# Altium schematic sheet Connector.SchDoc
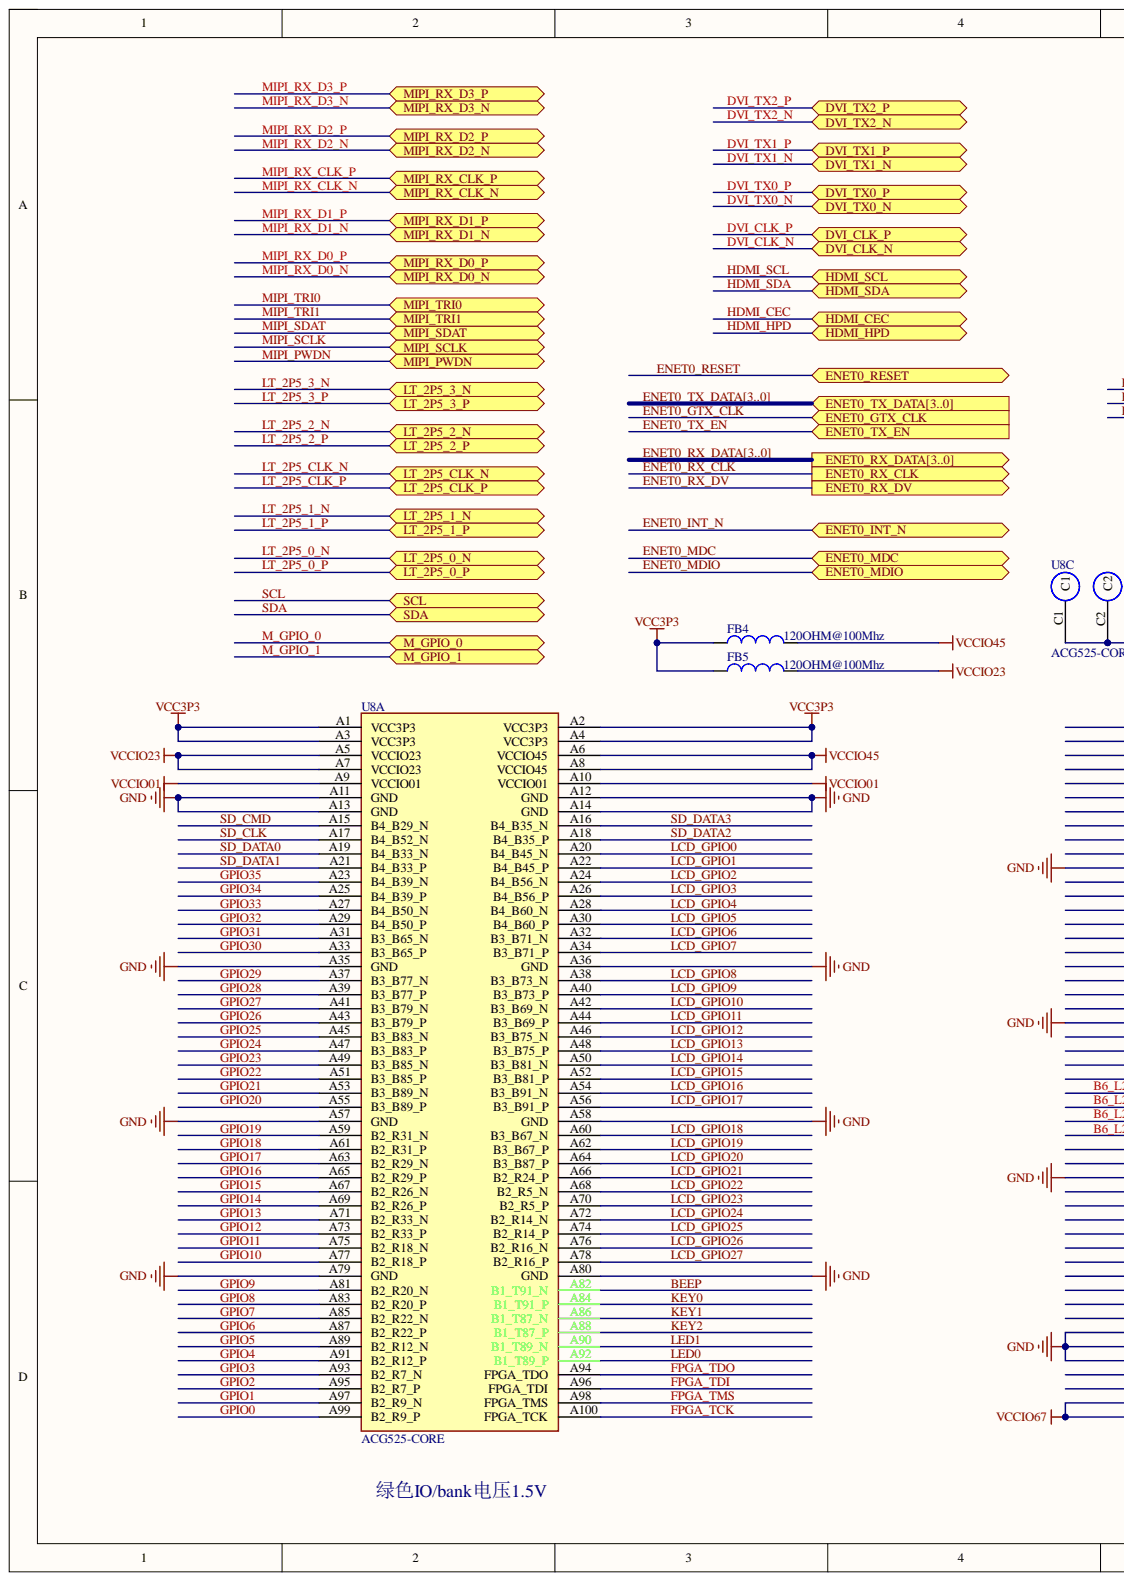
[diagram p0: Connector.SchDoc, left]
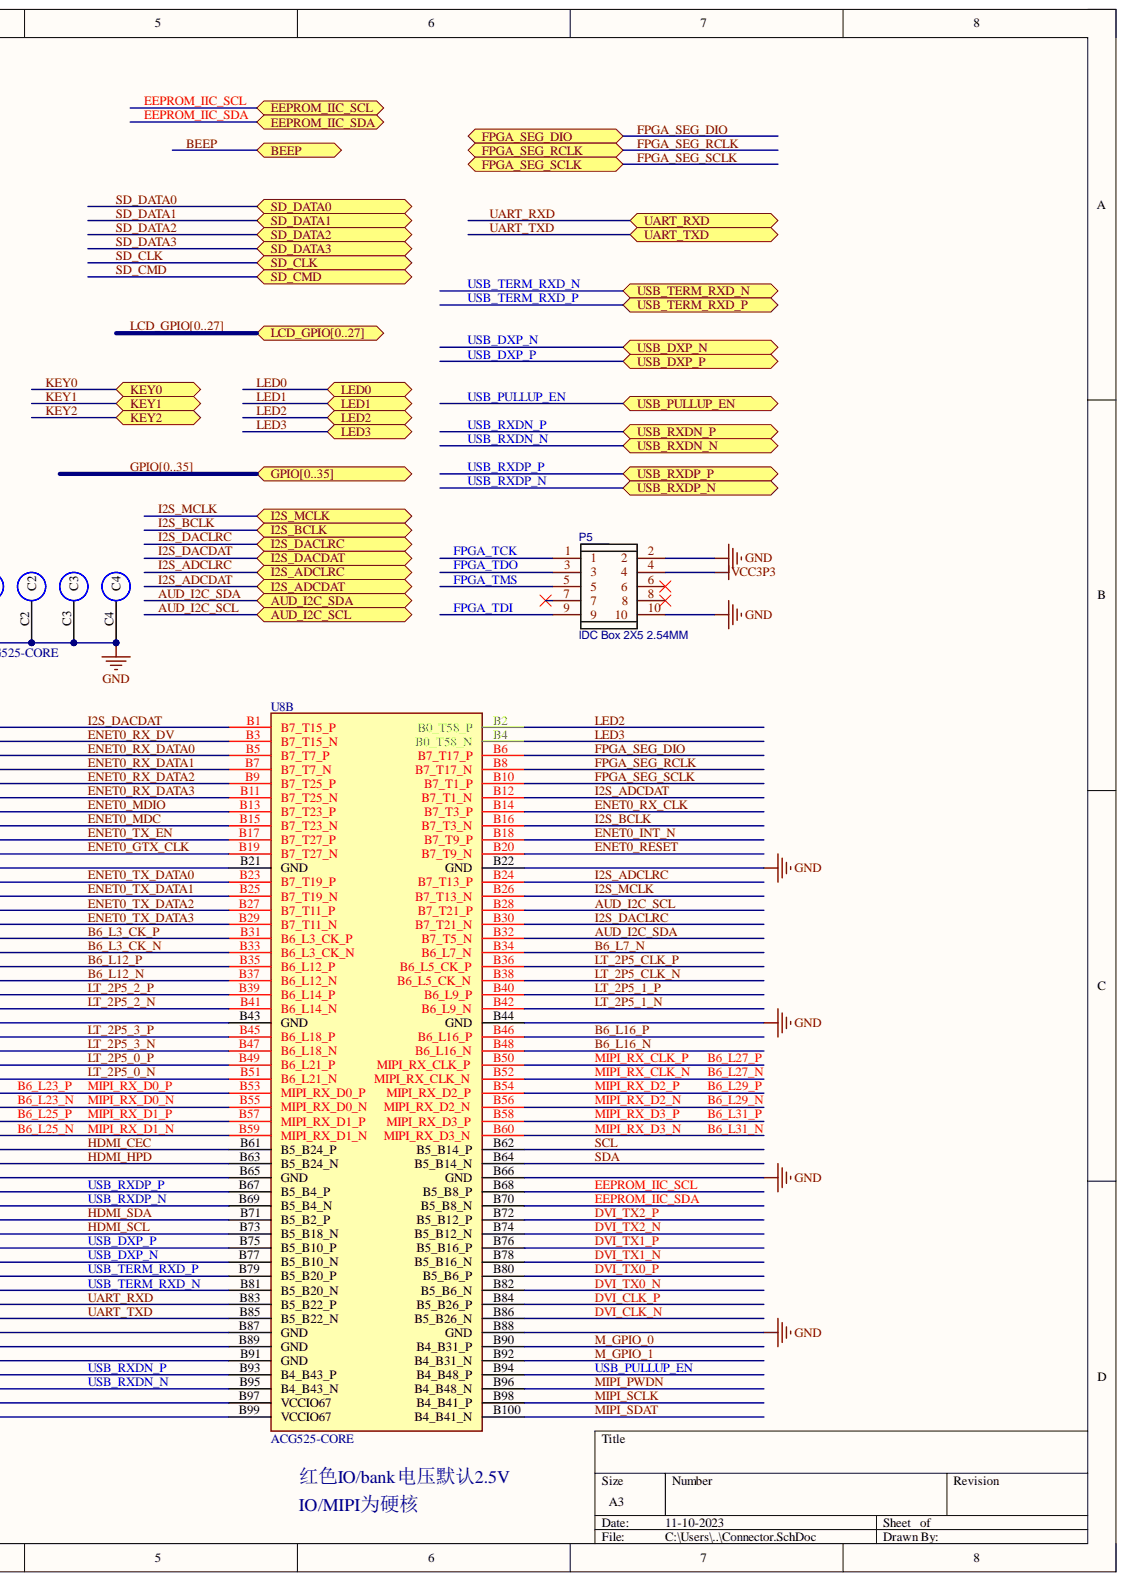
[diagram p1: Connector.SchDoc, right]

## Parts
FB4  120OHM@100Mhz  [L0603]
FB5  120OHM@100Mhz  [L0603]
P5  IDC Box 2X5 2.54MM  [idc-th_10p-p2_54-h-r2-c5-s2_54]
U8  ACG525-CORE  [ACG525-CORE-1110]  (parts A,B,C)

## Ports
AUD_I2C_SCL, AUD_I2C_SDA, BEEP, DVI_CLK_N, DVI_CLK_P, DVI_TX0_N, DVI_TX0_P, DVI_TX1_N, DVI_TX1_P, DVI_TX2_N, DVI_TX2_P, EEPROM_IIC_SCL, EEPROM_IIC_SDA, ENET0_GTX_CLK, ENET0_INT_N, ENET0_MDC, ENET0_MDIO, ENET0_RESET, ENET0_RX_CLK, ENET0_RX_DATA0, ENET0_RX_DATA1, ENET0_RX_DATA2, ENET0_RX_DATA3, ENET0_RX_DATA[3..0], ENET0_RX_DV, ENET0_TX_DATA0, ENET0_TX_DATA1, ENET0_TX_DATA2, ENET0_TX_DATA3, ENET0_TX_DATA[3..0], ENET0_TX_EN, FPGA_SEG_DIO, FPGA_SEG_RCLK, FPGA_SEG_SCLK, GPIO0, GPIO1, GPIO2, GPIO3, GPIO4, GPIO5, GPIO6, GPIO7, GPIO8, GPIO9, GPIO10, GPIO11, GPIO12, GPIO13, GPIO14, GPIO15, GPIO16, GPIO17, GPIO18, GPIO19, GPIO20, GPIO21, GPIO22, GPIO23, GPIO24, GPIO25, GPIO26, GPIO27, GPIO28, GPIO29, GPIO30, GPIO31, GPIO32, GPIO33, GPIO34, GPIO35, GPIO[0..35], HDMI_CEC, HDMI_HPD, HDMI_SCL, HDMI_SDA, I2S_ADCDAT, I2S_ADCLRC, I2S_BCLK, I2S_DACDAT, I2S_DACLRC, I2S_MCLK, KEY0, KEY1, KEY2, LCD_GPIO0, LCD_GPIO1, LCD_GPIO2, LCD_GPIO3, LCD_GPIO4, LCD_GPIO5, LCD_GPIO6, LCD_GPIO7, LCD_GPIO8, LCD_GPIO9, LCD_GPIO10, LCD_GPIO11, LCD_GPIO12, LCD_GPIO13, LCD_GPIO14, LCD_GPIO15, LCD_GPIO16, LCD_GPIO17, LCD_GPIO18, LCD_GPIO19, LCD_GPIO20, LCD_GPIO21, LCD_GPIO22, LCD_GPIO23, LCD_GPIO24, LCD_GPIO25, LCD_GPIO26, LCD_GPIO27, LCD_GPIO[0..27], LED0, LED1, LED2, LED3, LT_2P5_0_N, LT_2P5_0_P, LT_2P5_1_N, LT_2P5_1_P, LT_2P5_2_N, LT_2P5_2_P, LT_2P5_3_N, LT_2P5_3_P, LT_2P5_CLK_N, LT_2P5_CLK_P, M_GPIO_0, M_GPIO_1, MIPI_PWDN, MIPI_RX_CLK_N, MIPI_RX_CLK_P, MIPI_RX_D0_N, MIPI_RX_D0_P, MIPI_RX_D1_N, MIPI_RX_D1_P, MIPI_RX_D2_N, MIPI_RX_D2_P, MIPI_RX_D3_N, MIPI_RX_D3_P, MIPI_SCLK, MIPI_SDAT, MIPI_TRI0, MIPI_TRI1, SCL, SD_CLK, SD_CMD, SD_DATA0, SD_DATA1, SD_DATA2, SD_DATA3, SDA, UART_RXD, UART_TXD, USB_DXP_N, USB_DXP_P, USB_PULLUP_EN, USB_RXDN_N, USB_RXDN_P, USB_RXDP_N, USB_RXDP_P, USB_TERM_RXD_N, USB_TERM_RXD_P

## Nets
GND: P5.2 P5.10 U8.A11(GND) U8.A12(GND) U8.A13(GND) U8.A14(GND) U8.A35(GND) U8.A36(GND) U8.A57(GND) U8.A58(GND) U8.A79(GND) U8.A80(GND) U8.B21(GND) U8.B22(GND) U8.B43(GND) U8.B44(GND) U8.B65(GND) U8.B66(GND) U8.B87(GND) U8.B88(GND) U8.B89(GND) U8.B91(GND) U8.C1 U8.C2 U8.C3 U8.C4
VCC3P3: FB4.1 FB5.1 P5.4 U8.A1(VCC3P3) U8.A2(VCC3P3) U8.A3(VCC3P3) U8.A4(VCC3P3)
VCCIO01: U8.A9(VCCIO01) U8.A10(VCCIO01)
VCCIO23: FB5.2 U8.A5(VCCIO23) U8.A7(VCCIO23)
VCCIO45: FB4.2 U8.A6(VCCIO45) U8.A8(VCCIO45)
VCCIO67: U8.B97(VCCIO67) U8.B99(VCCIO67)
AUD_I2C_SCL: U8.B28(B7_T21_P) ; port AUD_I2C_SCL
AUD_I2C_SDA: U8.B32(B7_T5_N) ; port AUD_I2C_SDA
B6_L3_CK_N: U8.B33(B6_L3_CK_N)
B6_L3_CK_P: U8.B31(B6_L3_CK_P)
B6_L7_N: U8.B34(B6_L7_N)
B6_L12_N: U8.B37(B6_L12_N)
B6_L12_P: U8.B35(B6_L12_P)
B6_L16_N: U8.B48(B6_L16_N)
B6_L16_P: U8.B46(B6_L16_P)
B6_L23_N: U8.B55(MIPI_RX_D0_N) ; port MIPI_RX_D0_N
B6_L23_P: U8.B53(MIPI_RX_D0_P) ; port MIPI_RX_D0_P
B6_L25_N: U8.B59(MIPI_RX_D1_N) ; port MIPI_RX_D1_N
B6_L25_P: U8.B57(MIPI_RX_D1_P) ; port MIPI_RX_D1_P
B6_L27_N: U8.B52(MIPI_RX_CLK_N) ; port MIPI_RX_CLK_N
B6_L27_P: U8.B50(MIPI_RX_CLK_P) ; port MIPI_RX_CLK_P
B6_L29_N: U8.B56(MIPI_RX_D2_N) ; port MIPI_RX_D2_N
B6_L29_P: U8.B54(MIPI_RX_D2_P) ; port MIPI_RX_D2_P
B6_L31_N: U8.B60(MIPI_RX_D3_N) ; port MIPI_RX_D3_N
B6_L31_P: U8.B58(MIPI_RX_D3_P) ; port MIPI_RX_D3_P
BEEP: U8.A82(B1_T91_N) ; port BEEP
DVI_CLK_N: U8.B86(B5_B26_N) ; port DVI_CLK_N
DVI_CLK_P: U8.B84(B5_B26_P) ; port DVI_CLK_P
DVI_TX0_N: U8.B82(B5_B6_N) ; port DVI_TX0_N
DVI_TX0_P: U8.B80(B5_B6_P) ; port DVI_TX0_P
DVI_TX1_N: U8.B78(B5_B16_N) ; port DVI_TX1_N
DVI_TX1_P: U8.B76(B5_B16_P) ; port DVI_TX1_P
DVI_TX2_N: U8.B74(B5_B12_N) ; port DVI_TX2_N
DVI_TX2_P: U8.B72(B5_B12_P) ; port DVI_TX2_P
EEPROM_IIC_SCL: U8.B68(B5_B8_P) ; port EEPROM_IIC_SCL
EEPROM_IIC_SDA: U8.B70(B5_B8_N) ; port EEPROM_IIC_SDA
ENET0_GTX_CLK: U8.B19(B7_T27_N) ; port ENET0_GTX_CLK
ENET0_INT_N: U8.B18(B7_T9_P) ; port ENET0_INT_N
ENET0_MDC: U8.B15(B7_T23_N) ; port ENET0_MDC
ENET0_MDIO: U8.B13(B7_T23_P) ; port ENET0_MDIO
ENET0_RESET: U8.B20(B7_T9_N) ; port ENET0_RESET
ENET0_RX_CLK: U8.B14(B7_T3_P) ; port ENET0_RX_CLK
ENET0_RX_DATA0: U8.B5(B7_T7_P) ; port ENET0_RX_DATA[3..0]  [also labelled ENET0_RX_DATA]
ENET0_RX_DATA1: U8.B7(B7_T7_N) ; port ENET0_RX_DATA[3..0]  [also labelled ENET0_RX_DATA]
ENET0_RX_DATA2: U8.B9(B7_T25_P) ; port ENET0_RX_DATA[3..0]  [also labelled ENET0_RX_DATA]
ENET0_RX_DATA3: U8.B11(B7_T25_N) ; port ENET0_RX_DATA[3..0]  [also labelled ENET0_RX_DATA]
ENET0_RX_DV: U8.B3(B7_T15_N) ; port ENET0_RX_DV
ENET0_TX_DATA0: U8.B23(B7_T19_P) ; port ENET0_TX_DATA[3..0]  [also labelled ENET0_TX_DATA]
ENET0_TX_DATA1: U8.B25(B7_T19_N) ; port ENET0_TX_DATA[3..0]  [also labelled ENET0_TX_DATA]
ENET0_TX_DATA2: U8.B27(B7_T11_P) ; port ENET0_TX_DATA[3..0]  [also labelled ENET0_TX_DATA]
ENET0_TX_DATA3: U8.B29(B7_T11_N) ; port ENET0_TX_DATA[3..0]  [also labelled ENET0_TX_DATA]
ENET0_TX_EN: U8.B17(B7_T27_P) ; port ENET0_TX_EN
FPGA_SEG_DIO: U8.B6(B7_T17_P) ; port FPGA_SEG_DIO
FPGA_SEG_RCLK: U8.B8(B7_T17_N) ; port FPGA_SEG_RCLK
FPGA_SEG_SCLK: U8.B10(B7_T1_P) ; port FPGA_SEG_SCLK
FPGA_TCK: P5.1 U8.A100(FPGA_TCK)
FPGA_TDI: P5.9 U8.A96(FPGA_TDI)
FPGA_TDO: P5.3 U8.A94(FPGA_TDO)
FPGA_TMS: P5.5 U8.A98(FPGA_TMS)
GPIO0: U8.A99(B2_R9_P) ; port GPIO[0..35]  [also labelled GPIO]
GPIO1: U8.A97(B2_R9_N) ; port GPIO[0..35]  [also labelled GPIO]
GPIO2: U8.A95(B2_R7_P) ; port GPIO[0..35]  [also labelled GPIO]
GPIO3: U8.A93(B2_R7_N) ; port GPIO[0..35]  [also labelled GPIO]
GPIO4: U8.A91(B2_R12_P) ; port GPIO[0..35]  [also labelled GPIO]
GPIO5: U8.A89(B2_R12_N) ; port GPIO[0..35]  [also labelled GPIO]
GPIO6: U8.A87(B2_R22_P) ; port GPIO[0..35]  [also labelled GPIO]
GPIO7: U8.A85(B2_R22_N) ; port GPIO[0..35]  [also labelled GPIO]
GPIO8: U8.A83(B2_R20_P) ; port GPIO[0..35]  [also labelled GPIO]
GPIO9: U8.A81(B2_R20_N) ; port GPIO[0..35]  [also labelled GPIO]
GPIO10: U8.A77(B2_R18_P) ; port GPIO[0..35]  [also labelled GPIO]
GPIO11: U8.A75(B2_R18_N) ; port GPIO[0..35]  [also labelled GPIO]
GPIO12: U8.A73(B2_R33_P) ; port GPIO[0..35]  [also labelled GPIO]
GPIO13: U8.A71(B2_R33_N) ; port GPIO[0..35]  [also labelled GPIO]
GPIO14: U8.A69(B2_R26_P) ; port GPIO[0..35]  [also labelled GPIO]
GPIO15: U8.A67(B2_R26_N) ; port GPIO[0..35]  [also labelled GPIO]
GPIO16: U8.A65(B2_R29_P) ; port GPIO[0..35]  [also labelled GPIO]
GPIO17: U8.A63(B2_R29_N) ; port GPIO[0..35]  [also labelled GPIO]
GPIO18: U8.A61(B2_R31_P) ; port GPIO[0..35]  [also labelled GPIO]
GPIO19: U8.A59(B2_R31_N) ; port GPIO[0..35]  [also labelled GPIO]
GPIO20: U8.A55(B3_B89_P) ; port GPIO[0..35]  [also labelled GPIO]
GPIO21: U8.A53(B3_B89_N) ; port GPIO[0..35]  [also labelled GPIO]
GPIO22: U8.A51(B3_B85_P) ; port GPIO[0..35]  [also labelled GPIO]
GPIO23: U8.A49(B3_B85_N) ; port GPIO[0..35]  [also labelled GPIO]
GPIO24: U8.A47(B3_B83_P) ; port GPIO[0..35]  [also labelled GPIO]
GPIO25: U8.A45(B3_B83_N) ; port GPIO[0..35]  [also labelled GPIO]
GPIO26: U8.A43(B3_B79_P) ; port GPIO[0..35]  [also labelled GPIO]
GPIO27: U8.A41(B3_B79_N) ; port GPIO[0..35]  [also labelled GPIO]
GPIO28: U8.A39(B3_B77_P) ; port GPIO[0..35]  [also labelled GPIO]
GPIO29: U8.A37(B3_B77_N) ; port GPIO[0..35]  [also labelled GPIO]
GPIO30: U8.A33(B3_B65_P) ; port GPIO[0..35]  [also labelled GPIO]
GPIO31: U8.A31(B3_B65_N) ; port GPIO[0..35]  [also labelled GPIO]
GPIO32: U8.A29(B4_B50_P) ; port GPIO[0..35]  [also labelled GPIO]
GPIO33: U8.A27(B4_B50_N) ; port GPIO[0..35]  [also labelled GPIO]
GPIO34: U8.A25(B4_B39_P) ; port GPIO[0..35]  [also labelled GPIO]
GPIO35: U8.A23(B4_B39_N) ; port GPIO[0..35]  [also labelled GPIO]
HDMI_CEC: U8.B61(B5_B24_P) ; port HDMI_CEC
HDMI_HPD: U8.B63(B5_B24_N) ; port HDMI_HPD
HDMI_SCL: U8.B73(B5_B18_N) ; port HDMI_SCL
HDMI_SDA: U8.B71(B5_B2_P) ; port HDMI_SDA
I2S_ADCDAT: U8.B12(B7_T1_N) ; port I2S_ADCDAT
I2S_ADCLRC: U8.B24(B7_T13_P) ; port I2S_ADCLRC
I2S_BCLK: U8.B16(B7_T3_N) ; port I2S_BCLK
I2S_DACDAT: U8.B1(B7_T15_P) ; port I2S_DACDAT
I2S_DACLRC: U8.B30(B7_T21_N) ; port I2S_DACLRC
I2S_MCLK: U8.B26(B7_T13_N) ; port I2S_MCLK
KEY0: U8.A84(B1_T91_P) ; port KEY0
KEY1: U8.A86(B1_T87_N) ; port KEY1
KEY2: U8.A88(B1_T87_P) ; port KEY2
LCD_GPIO0: U8.A20(B4_B45_N) ; port LCD_GPIO[0..27]  [also labelled LCD_GPIO]
LCD_GPIO1: U8.A22(B4_B45_P) ; port LCD_GPIO[0..27]  [also labelled LCD_GPIO]
LCD_GPIO2: U8.A24(B4_B56_N) ; port LCD_GPIO[0..27]  [also labelled LCD_GPIO]
LCD_GPIO3: U8.A26(B4_B56_P) ; port LCD_GPIO[0..27]  [also labelled LCD_GPIO]
LCD_GPIO4: U8.A28(B4_B60_N) ; port LCD_GPIO[0..27]  [also labelled LCD_GPIO]
LCD_GPIO5: U8.A30(B4_B60_P) ; port LCD_GPIO[0..27]  [also labelled LCD_GPIO]
LCD_GPIO6: U8.A32(B3_B71_N) ; port LCD_GPIO[0..27]  [also labelled LCD_GPIO]
LCD_GPIO7: U8.A34(B3_B71_P) ; port LCD_GPIO[0..27]  [also labelled LCD_GPIO]
LCD_GPIO8: U8.A38(B3_B73_N) ; port LCD_GPIO[0..27]  [also labelled LCD_GPIO]
LCD_GPIO9: U8.A40(B3_B73_P) ; port LCD_GPIO[0..27]  [also labelled LCD_GPIO]
LCD_GPIO10: U8.A42(B3_B69_N) ; port LCD_GPIO[0..27]  [also labelled LCD_GPIO]
LCD_GPIO11: U8.A44(B3_B69_P) ; port LCD_GPIO[0..27]  [also labelled LCD_GPIO]
LCD_GPIO12: U8.A46(B3_B75_N) ; port LCD_GPIO[0..27]  [also labelled LCD_GPIO]
LCD_GPIO13: U8.A48(B3_B75_P) ; port LCD_GPIO[0..27]  [also labelled LCD_GPIO]
LCD_GPIO14: U8.A50(B3_B81_N) ; port LCD_GPIO[0..27]  [also labelled LCD_GPIO]
LCD_GPIO15: U8.A52(B3_B81_P) ; port LCD_GPIO[0..27]  [also labelled LCD_GPIO]
LCD_GPIO16: U8.A54(B3_B91_N) ; port LCD_GPIO[0..27]  [also labelled LCD_GPIO]
LCD_GPIO17: U8.A56(B3_B91_P) ; port LCD_GPIO[0..27]  [also labelled LCD_GPIO]
LCD_GPIO18: U8.A60(B3_B67_N) ; port LCD_GPIO[0..27]  [also labelled LCD_GPIO]
LCD_GPIO19: U8.A62(B3_B67_P) ; port LCD_GPIO[0..27]  [also labelled LCD_GPIO]
LCD_GPIO20: U8.A64(B3_B87_P) ; port LCD_GPIO[0..27]  [also labelled LCD_GPIO]
LCD_GPIO21: U8.A66(B2_R24_P) ; port LCD_GPIO[0..27]  [also labelled LCD_GPIO]
LCD_GPIO22: U8.A68(B2_R5_N) ; port LCD_GPIO[0..27]  [also labelled LCD_GPIO]
LCD_GPIO23: U8.A70(B2_R5_P) ; port LCD_GPIO[0..27]  [also labelled LCD_GPIO]
LCD_GPIO24: U8.A72(B2_R14_N) ; port LCD_GPIO[0..27]  [also labelled LCD_GPIO]
LCD_GPIO25: U8.A74(B2_R14_P) ; port LCD_GPIO[0..27]  [also labelled LCD_GPIO]
LCD_GPIO26: U8.A76(B2_R16_N) ; port LCD_GPIO[0..27]  [also labelled LCD_GPIO]
LCD_GPIO27: U8.A78(B2_R16_P) ; port LCD_GPIO[0..27]  [also labelled LCD_GPIO]
LED0: U8.A92(B1_T89_P) ; port LED0
LED1: U8.A90(B1_T89_N) ; port LED1
LED2: U8.B2(B0_T58_P) ; port LED2
LED3: U8.B4(B0_T58_N) ; port LED3
LT_2P5_0_N: U8.B51(B6_L21_N) ; port LT_2P5_0_N
LT_2P5_0_P: U8.B49(B6_L21_P) ; port LT_2P5_0_P
LT_2P5_1_N: U8.B42(B6_L9_N) ; port LT_2P5_1_N
LT_2P5_1_P: U8.B40(B6_L9_P) ; port LT_2P5_1_P
LT_2P5_2_N: U8.B41(B6_L14_N) ; port LT_2P5_2_N
LT_2P5_2_P: U8.B39(B6_L14_P) ; port LT_2P5_2_P
LT_2P5_3_N: U8.B47(B6_L18_N) ; port LT_2P5_3_N
LT_2P5_3_P: U8.B45(B6_L18_P) ; port LT_2P5_3_P
LT_2P5_CLK_N: U8.B38(B6_L5_CK_N) ; port LT_2P5_CLK_N
LT_2P5_CLK_P: U8.B36(B6_L5_CK_P) ; port LT_2P5_CLK_P
M_GPIO_0: U8.B90(B4_B31_P) ; port M_GPIO_0
M_GPIO_1: U8.B92(B4_B31_N) ; port M_GPIO_1
MIPI_PWDN: U8.B96(B4_B48_N) ; port MIPI_PWDN
MIPI_SCLK: U8.B98(B4_B41_P) ; port MIPI_SCLK
MIPI_SDAT: U8.B100(B4_B41_N) ; port MIPI_SDAT
SCL: U8.B62(B5_B14_P) ; port SCL
SD_CLK: U8.A17(B4_B52_N) ; port SD_CLK
SD_CMD: U8.A15(B4_B29_N) ; port SD_CMD
SD_DATA0: U8.A19(B4_B33_N) ; port SD_DATA0
SD_DATA1: U8.A21(B4_B33_P) ; port SD_DATA1
SD_DATA2: U8.A18(B4_B35_P) ; port SD_DATA2
SD_DATA3: U8.A16(B4_B35_N) ; port SD_DATA3
SDA: U8.B64(B5_B14_N) ; port SDA
UART_RXD: U8.B83(B5_B22_P) ; port UART_RXD
UART_TXD: U8.B85(B5_B22_N) ; port UART_TXD
USB_DXP_N: U8.B77(B5_B10_N) ; port USB_DXP_N
USB_DXP_P: U8.B75(B5_B10_P) ; port USB_DXP_P
USB_PULLUP_EN: U8.B94(B4_B48_P) ; port USB_PULLUP_EN
USB_RXDN_N: U8.B95(B4_B43_N) ; port USB_RXDN_N
USB_RXDN_P: U8.B93(B4_B43_P) ; port USB_RXDN_P
USB_RXDP_N: U8.B69(B5_B4_N) ; port USB_RXDP_N
USB_RXDP_P: U8.B67(B5_B4_P) ; port USB_RXDP_P
USB_TERM_RXD_N: U8.B81(B5_B20_N) ; port USB_TERM_RXD_N
USB_TERM_RXD_P: U8.B79(B5_B20_P) ; port USB_TERM_RXD_P
MIPI_TRI0: ; port MIPI_TRI0
MIPI_TRI1: ; port MIPI_TRI1

Not connected: P5 6 7 8

## Library data of the parts
FB4 FB5 = L: Inductor; Component Kind: Standard; Library Name: plm60x_v001.SchLib
P5 = IDC Box 2X5 2.54MM: BOM_Manufacturer: BOOMELE; BOM_JLCPCB Part Class: Extended Part; BOM_Manufacturer Part: IDC Box 2X5 2.54MM; BOM_Supplier: LCSC; BOM_Supplier Part: C9140; Component Kind: Standard; Library Name: H154.SchLib; spicePre: P; spiceSymbolName: IDC Box 2X5 2.54MM
U8 = ACG525-CORE: Component Kind: Standard; Description: ACG525-CORE; Library Name: ACG525-CORE.SchLib
